# Altium schematic sheet 01_MCU.SchDoc
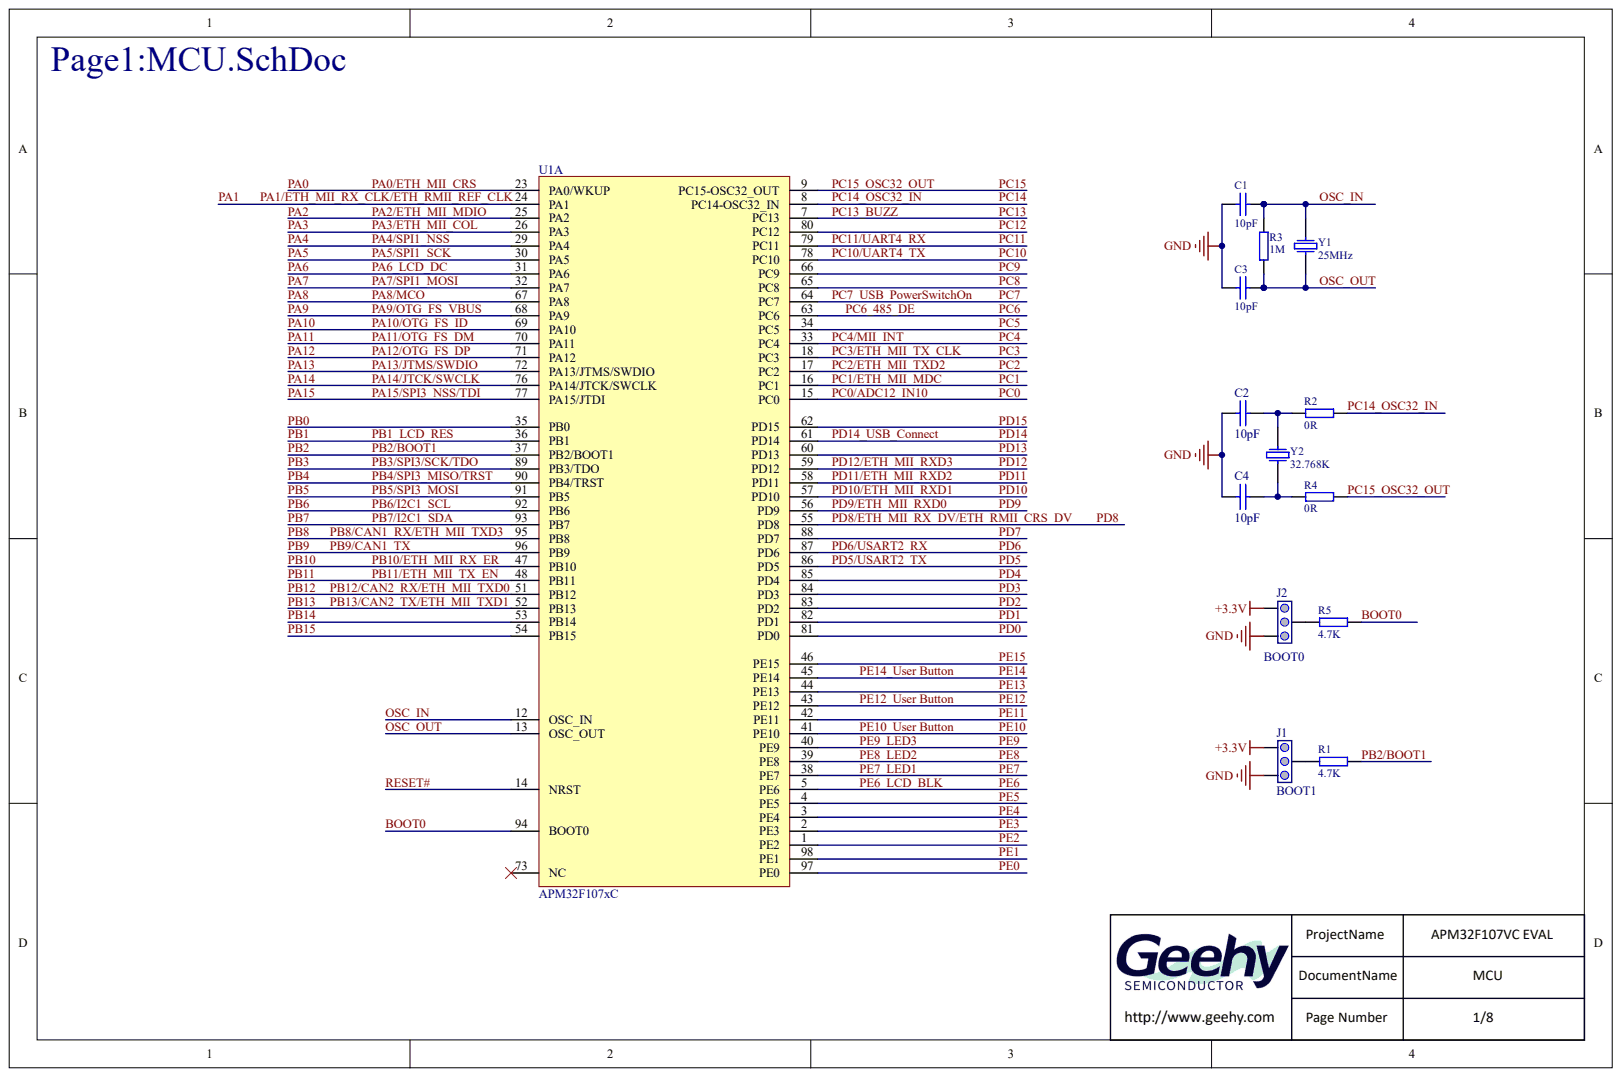
[diagram p0: 01_MCU.SchDoc]

## Parts
C1  10pF
C2  10pF
C3  10pF
C4  10pF
J1  BOOT1
J2  BOOT0
R1  4.7K
R2  0R
R3  1M
R4  0R
R5  4.7K
U1  APM32F107xC  (part A)
Y1  25MHz
Y2  32.768K

## Nets
+3.3V: J1.1 J2.1
GND: C1.2 C2.2 C3.2 C4.2 J1.3 J2.3
BOOT0: R5.2 U1.94(BOOT0)
OSC_IN: C1.1 R3.1 U1.12(OSC_IN) Y1.1
OSC_OUT: C3.1 R3.2 U1.13(OSC_OUT) Y1.2
PA0: U1.23(PA0/WKUP)
PA1: U1.24(PA1)
PA2: U1.25(PA2)
PA3: U1.26(PA3)
PA4: U1.29(PA4)
PA5: U1.30(PA5)
PA6: U1.31(PA6)
PA7: U1.32(PA7)
PA8: U1.67(PA8)
PA9: U1.68(PA9)
PA10: U1.69(PA10)
PA11: U1.70(PA11)
PA12: U1.71(PA12)
PA13: U1.72(PA13/JTMS/SWDIO)
PA14: U1.76(PA14/JTCK/SWCLK)
PA15: U1.77(PA15/JTDI)
PB0: U1.35(PB0)
PB1: U1.36(PB1)
PB2: R1.2 U1.37(PB2/BOOT1)
PB3: U1.89(PB3/TDO)
PB4: U1.90(PB4/TRST)
PB5: U1.91(PB5)
PB6: U1.92(PB6)
PB7: U1.93(PB7)
PB8: U1.95(PB8)
PB9: U1.96(PB9)
PB10: U1.47(PB10)
PB11: U1.48(PB11)
PB12: U1.51(PB12)
PB13: U1.52(PB13)
PB14: U1.53(PB14)
PB15: U1.54(PB15)
PC0: U1.15(PC0)
PC1: U1.16(PC1)
PC2: U1.17(PC2)
PC3: U1.18(PC3)
PC4: U1.33(PC4)
PC5: U1.34(PC5)
PC6: U1.63(PC6)
PC7: U1.64(PC7)
PC8: U1.65(PC8)
PC9: U1.66(PC9)
PC10: U1.78(PC10)
PC11: U1.79(PC11)
PC12: U1.80(PC12)
PC13: U1.7(PC13)
PC14: R2.2 U1.8(PC14-OSC32_IN)
PC15: R4.2 U1.9(PC15-OSC32_OUT)
PD0: U1.81(PD0)
PD1: U1.82(PD1)
PD2: U1.83(PD2)
PD3: U1.84(PD3)
PD4: U1.85(PD4)
PD5: U1.86(PD5)
PD6: U1.87(PD6)
PD7: U1.88(PD7)
PD8: U1.55(PD8)
PD9: U1.56(PD9)
PD10: U1.57(PD10)
PD11: U1.58(PD11)
PD12: U1.59(PD12)
PD13: U1.60(PD13)
PD14: U1.61(PD14)
PD15: U1.62(PD15)
PE0: U1.97(PE0)
PE1: U1.98(PE1)
PE2: U1.1(PE2)
PE3: U1.2(PE3)
PE4: U1.3(PE4)
PE5: U1.4(PE5)
PE6: U1.5(PE6)
PE7: U1.38(PE7)
PE8: U1.39(PE8)
PE9: U1.40(PE9)
PE10: U1.41(PE10)
PE11: U1.42(PE11)
PE12: U1.43(PE12)
PE13: U1.44(PE13)
PE14: U1.45(PE14)
PE15: U1.46(PE15)
RESET#: U1.14(NRST)
NetC2_1: C2.1 R2.1 Y2.1
NetC4_1: C4.1 R4.1 Y2.2
NetJ1_2: J1.2 R1.1
NetJ2_2: J2.2 R5.1

Not connected: U1 73(NC)

## Library data of the parts
C1 C3 = (no symbol name): Value: 100pF; Published: 8-Jun-2000; LatestRevisionDate: 17-Jul-2002; LatestRevisionNote: Re-released for DXP Platform.; PackageReference: RAD-0.3; PackageDescription: Radial Cap, Thru-Hole; 2 Leads; 0.3 in Pin Spacing; Publisher: Altium Limited
